# Altium schematic sheet ESP8266_DEVKIT_RELAY.SchDoc(ESP8266_DEVKIT_RELAY)
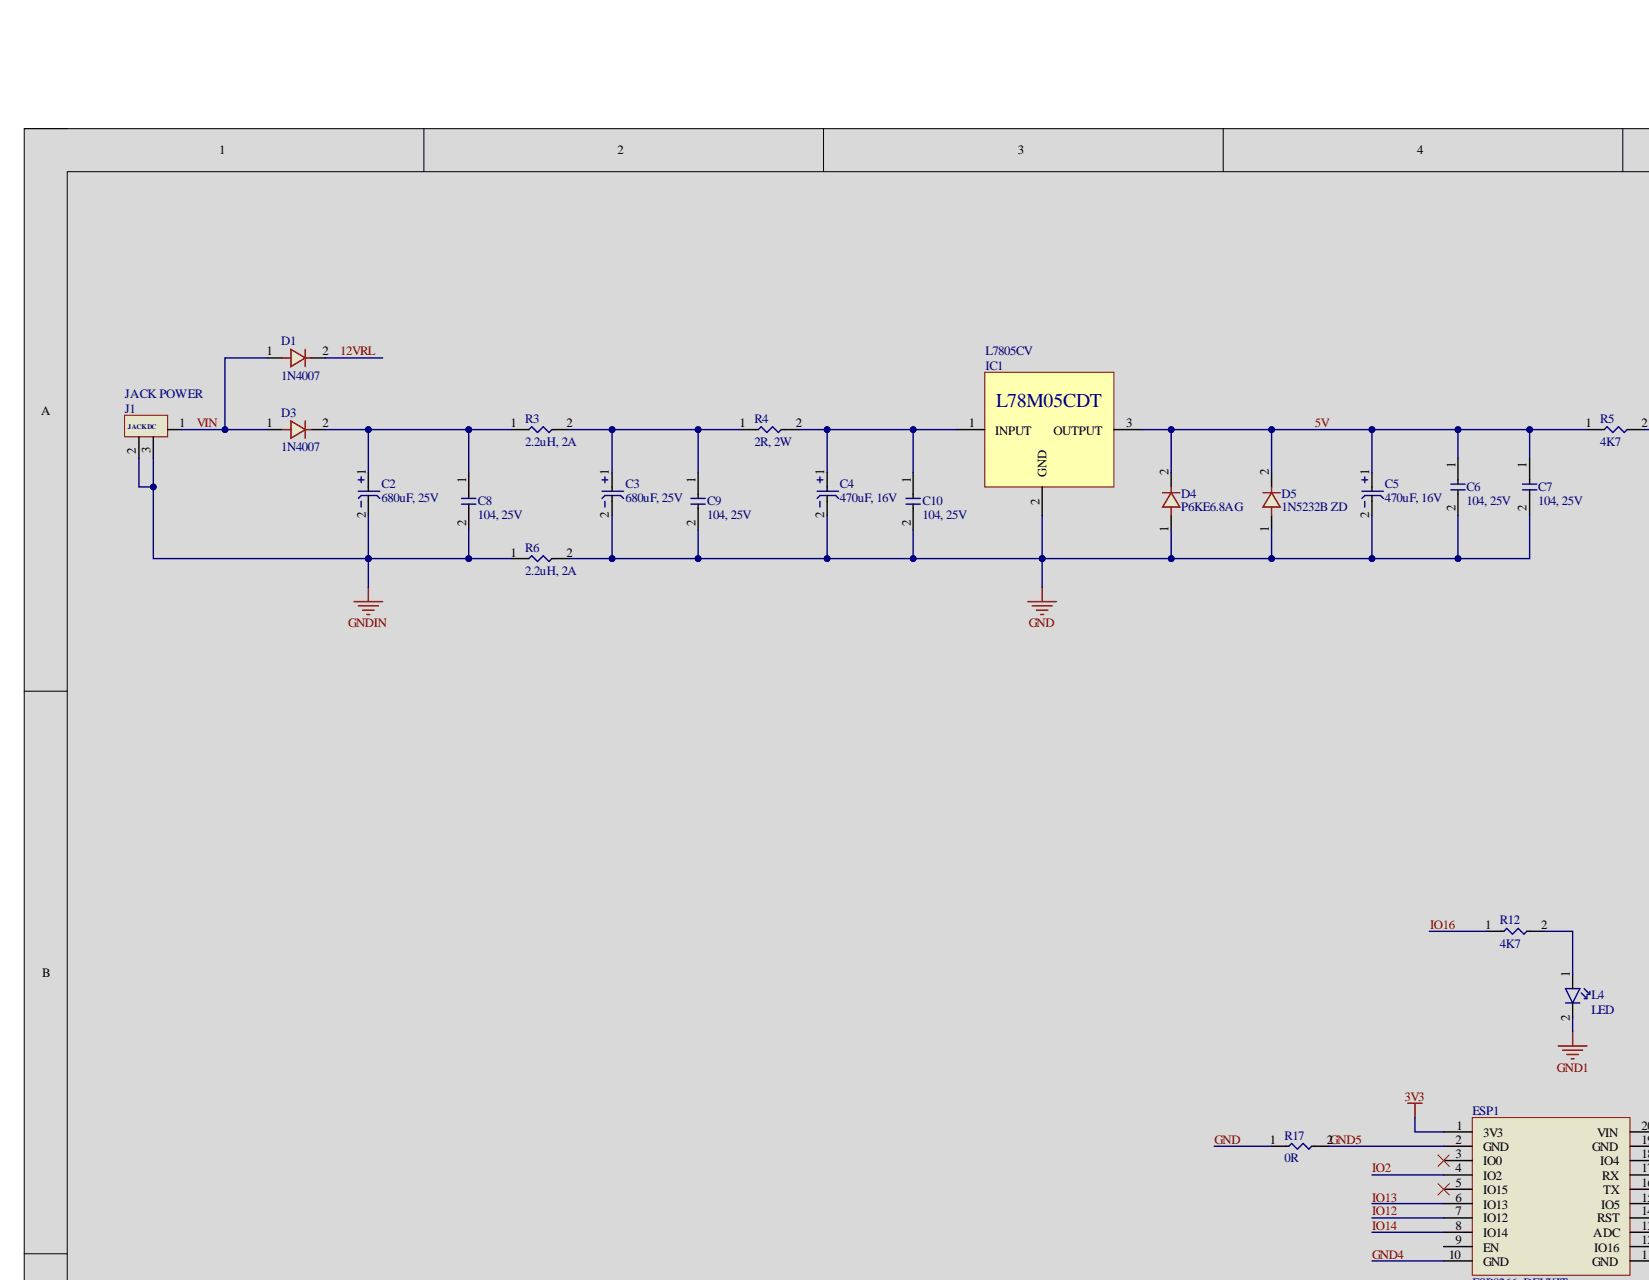
[diagram p0: ESP8266_DEVKIT_RELAY.SchDoc, top left]
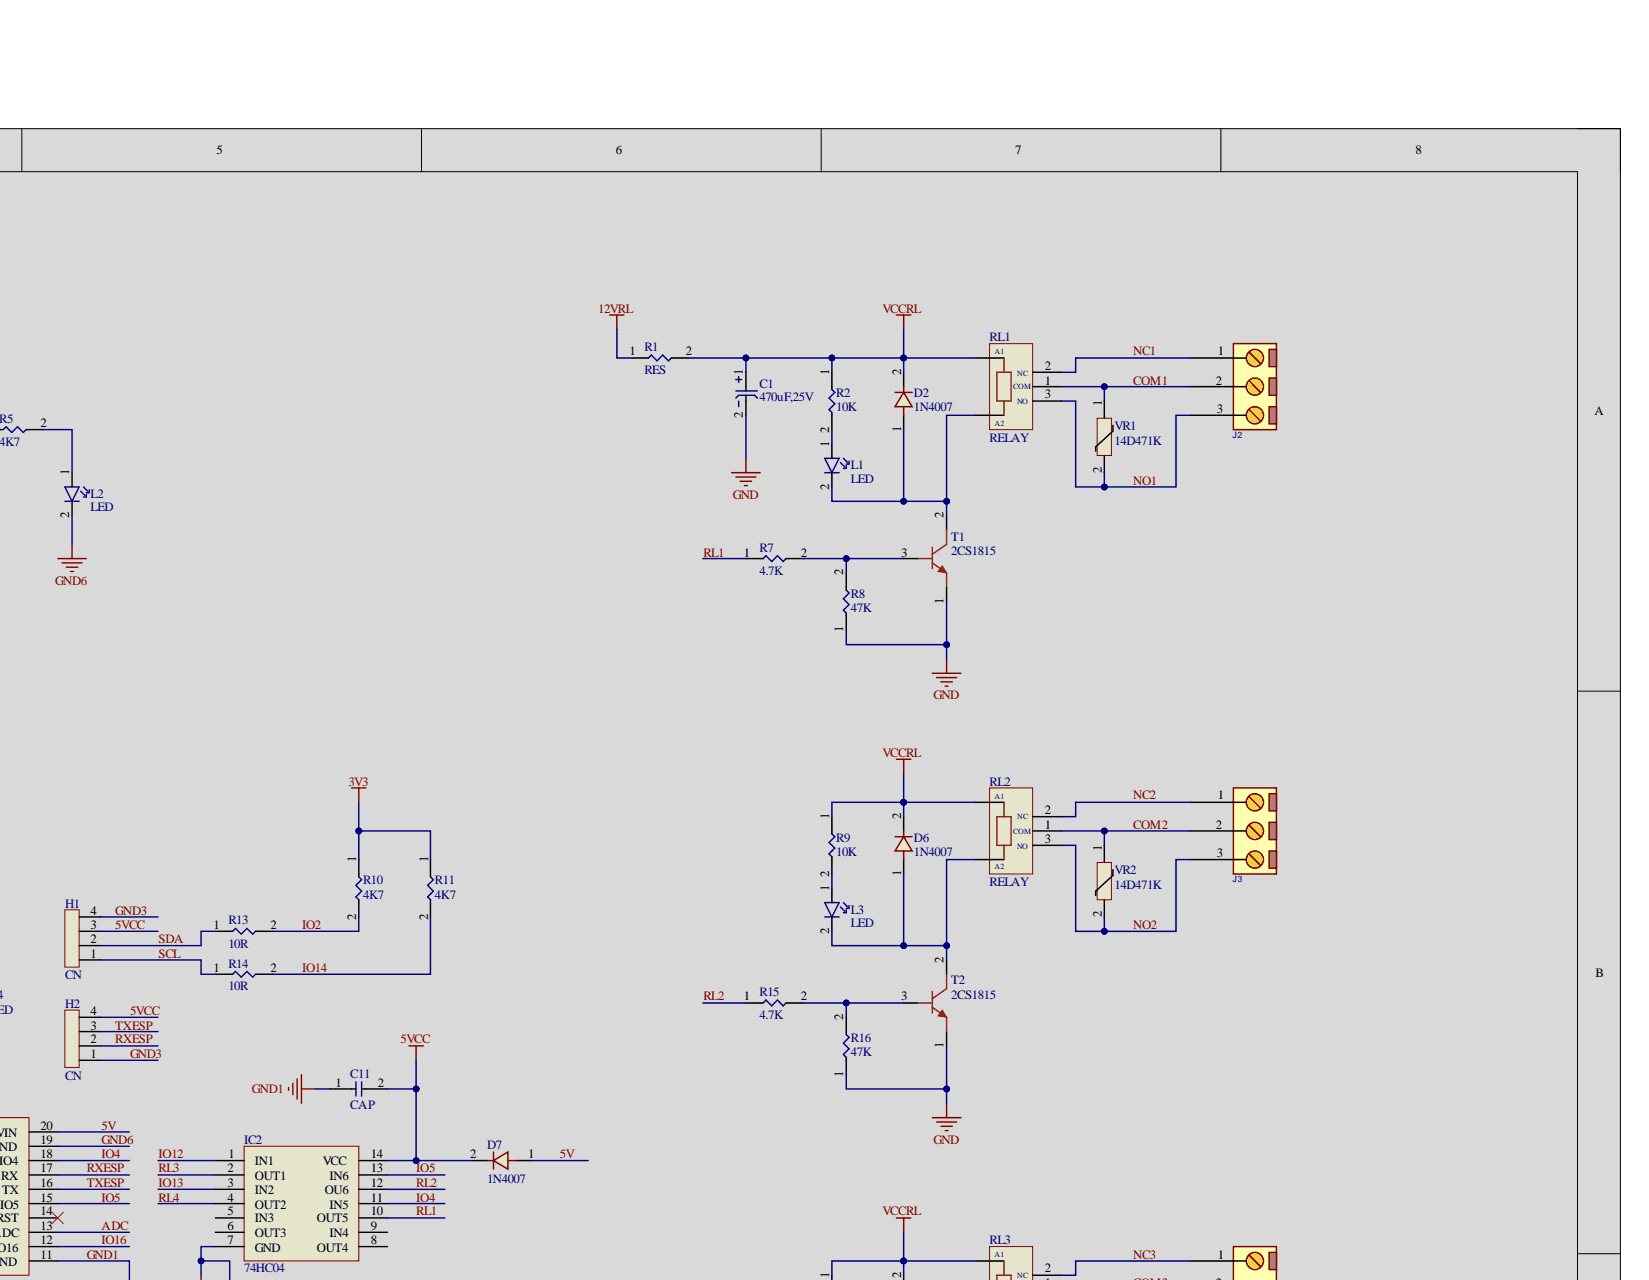
[diagram p1: ESP8266_DEVKIT_RELAY.SchDoc, top right]
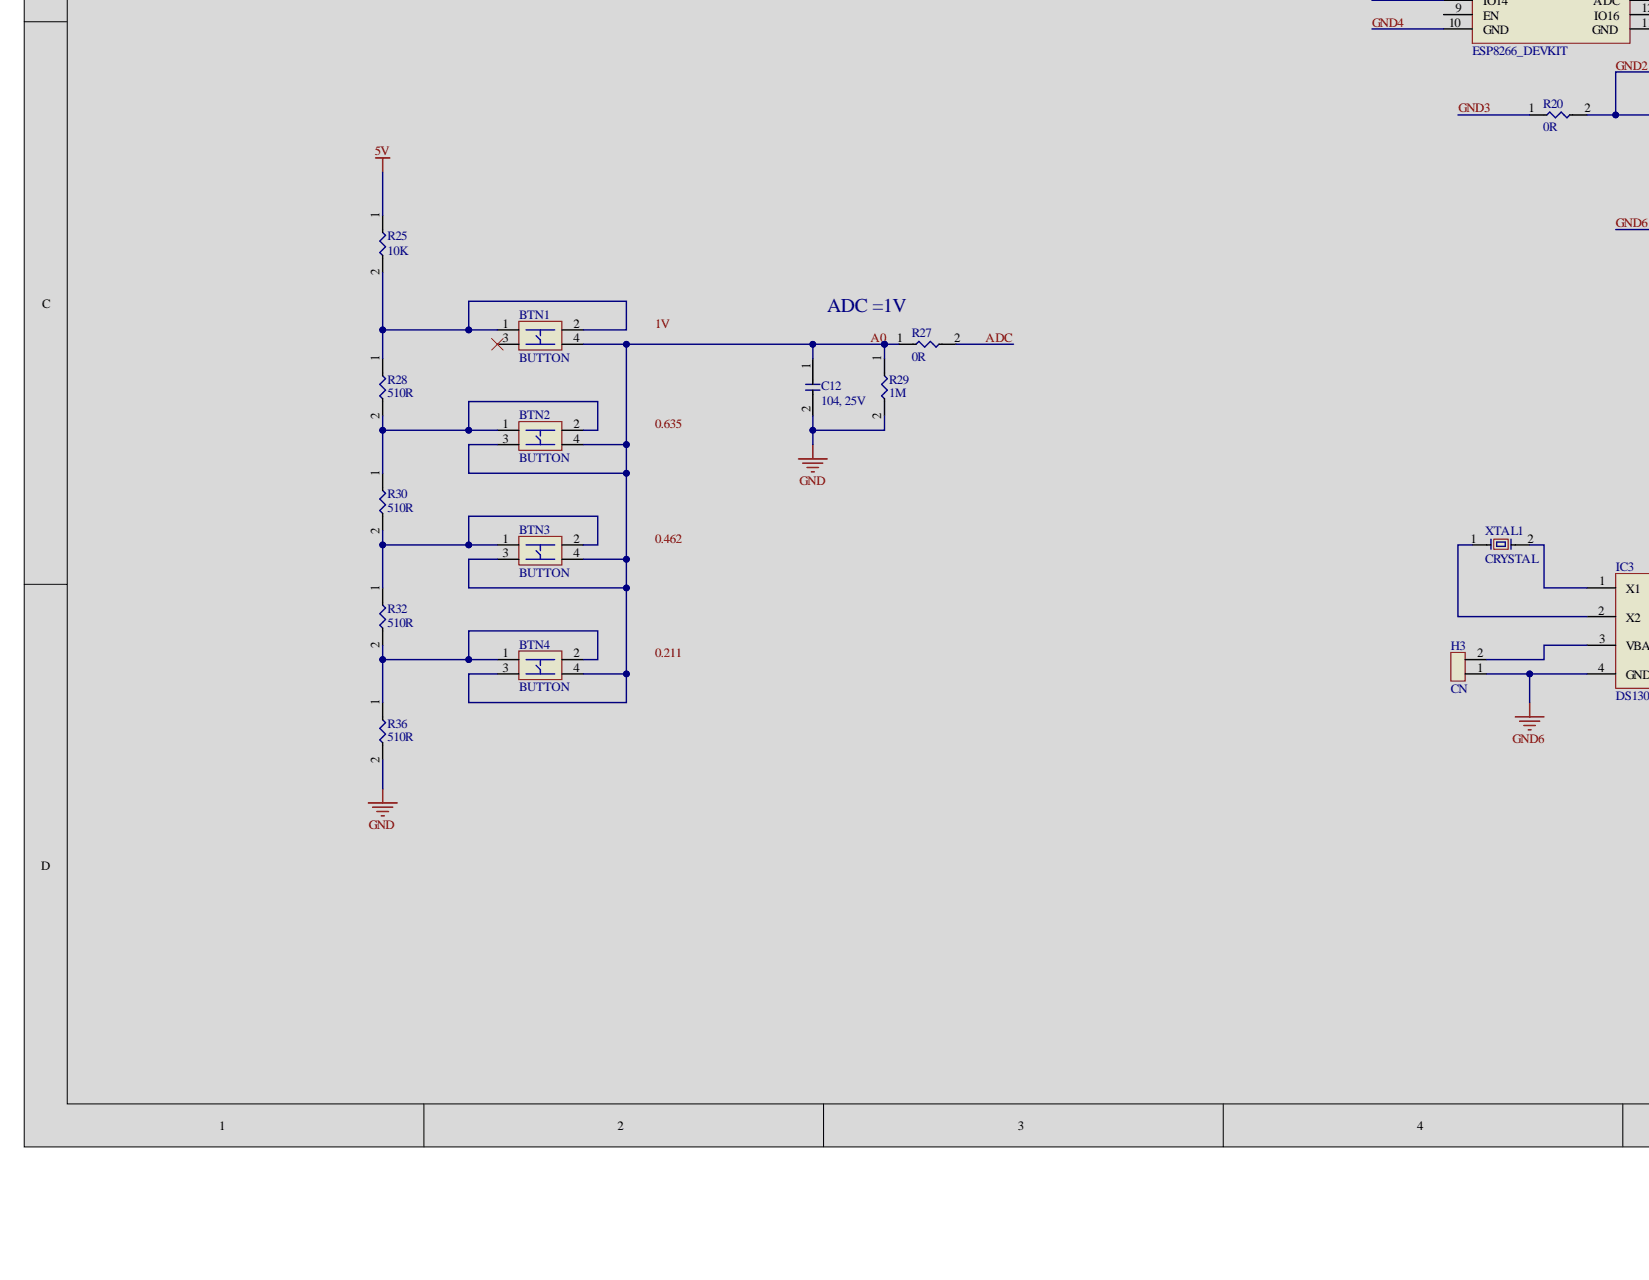
[diagram p2: ESP8266_DEVKIT_RELAY.SchDoc, bottom left]
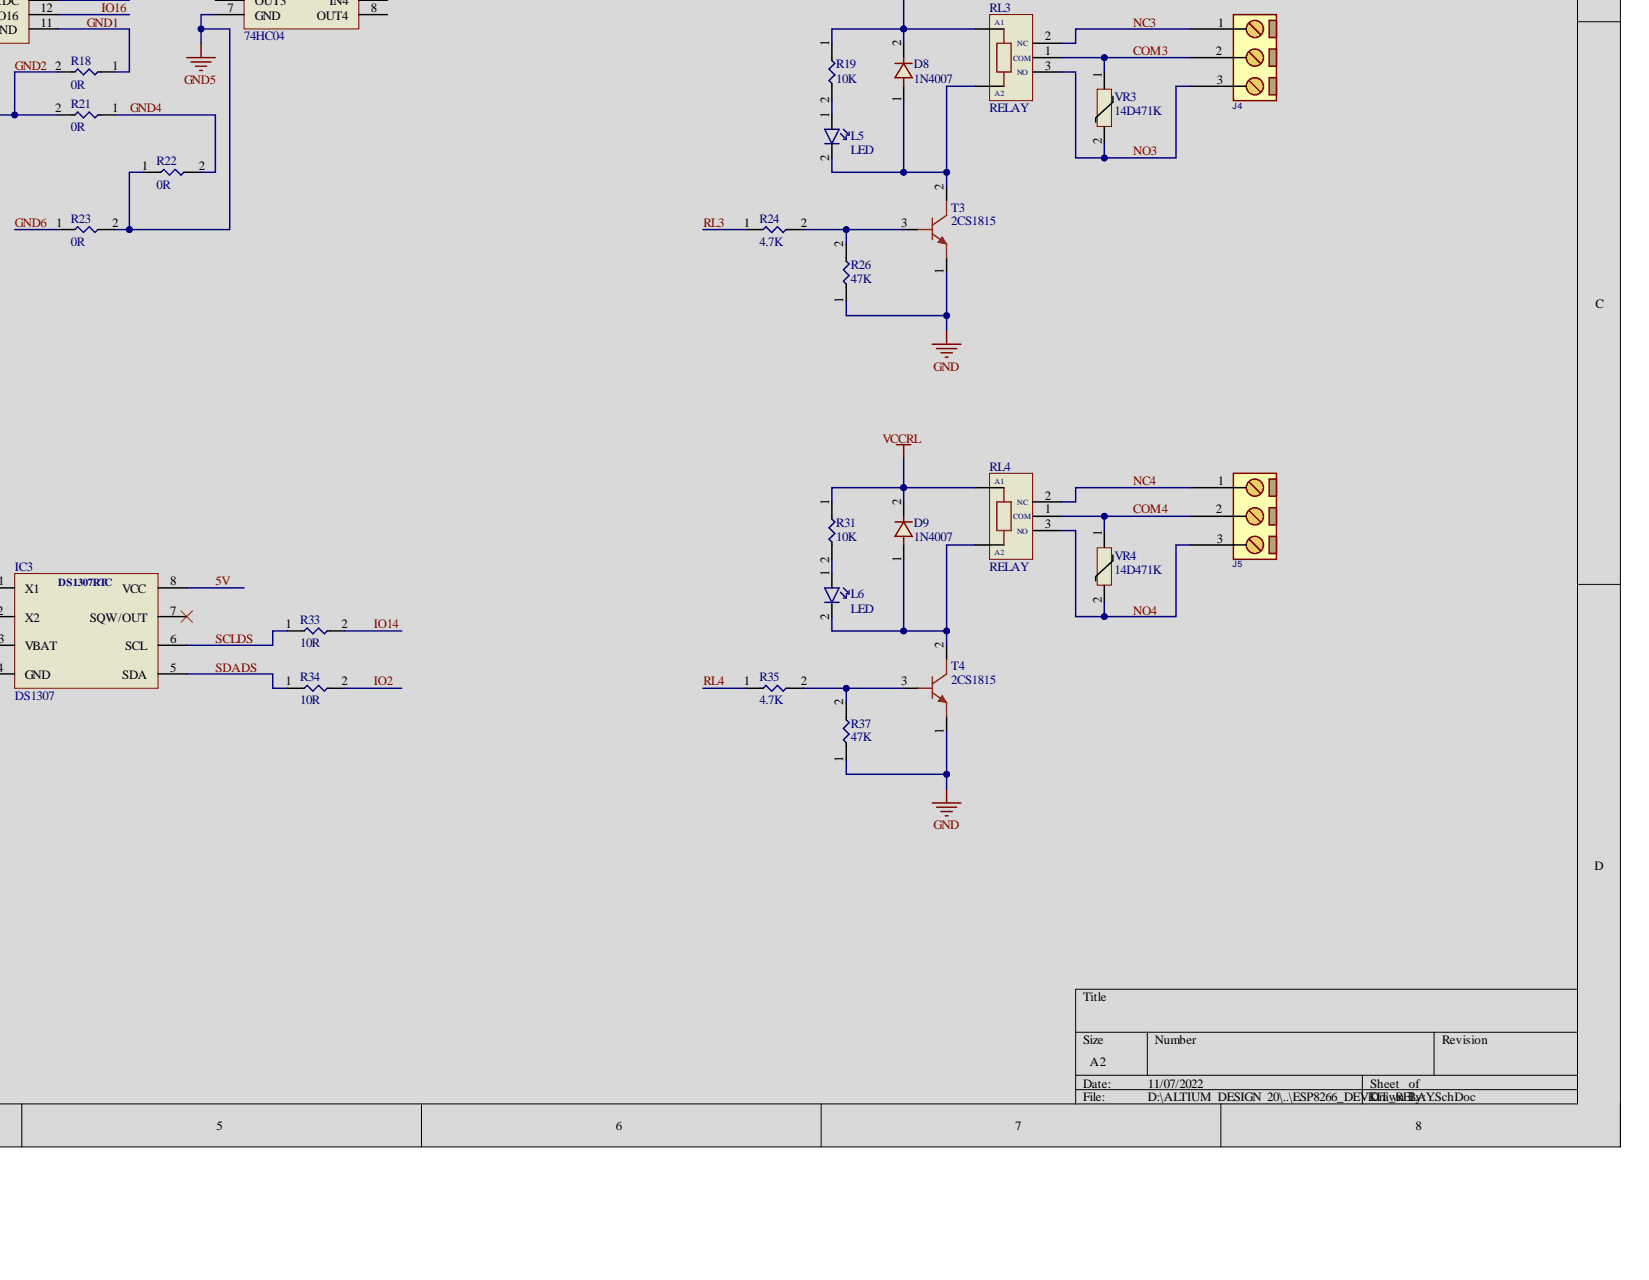
[diagram p3: ESP8266_DEVKIT_RELAY.SchDoc, bottom right]

## Parts
BTN1  BUTTON  [6X6X8MM]
BTN2  BUTTON  [6X6X8MM]
BTN3  BUTTON  [6X6X8MM]
BTN4  BUTTON  [6X6X8MM]
C1  470uF,25V  [CAP_POL_35MM]
C2  680uF, 25V  [CAP_POL_DIP]
C3  680uF, 25V  [CAP_POL_DIP]
C4  470uF, 16V  [CAP_POL_35MM]
C5  470uF, 16V  [CAP_POL_35MM]
C6  104, 25V  [CAP_CER_DC]
C7  104, 25V  [CAP_CER_DC]
C8  104, 25V  [CAP_CER_DC]
C9  104, 25V  [CAP_CER_DC]
C10  104, 25V  [CAP_CER_DC]
C11  CAP  [CAP_CER_DC]
C12  104, 25V  [CAP_CER_DC]
D1  1N4007  [DO-41]
D2  1N4007  [DO-41]
D3  1N4007  [DO-41]
D4  P6KE6.8AG  [DO-41]
D5  1N5232B ZD  [DO-41]
D6  1N4007  [DO-41]
D7  1N4007  [DO-41]
D8  1N4007  [DO-41]
D9  1N4007  [DO-41]
ESP1  ESP8266_DEVKIT  [ESP8266_DEVKIT]
H1  CN  [4P_DT_254MM]
H2  CN  [4P_DT_254MM]
H3  CN  [2P_254MM_T]
IC1  L7805CV  [TO-220]
IC2  74HC04  [DIP14]
IC3  DS1307  [DIP8]
J1  JACK POWER  [DC POWER]
J2  CONN_TB3  [P_TBG_3]  (value not drawn)
J3  CONN_TB3  [P_TBG_3]  (value not drawn)
J4  CONN_TB3  [P_TBG_3]  (value not drawn)
J5  CONN_TB3  [P_TBG_3]  (value not drawn)
L1  LED  [LED_3MM_DIP]
L2  LED  [LED_3MM_DIP]
L3  LED  [LED_3MM_DIP]
L4  LED  [LED_3MM_DIP]
L5  LED  [LED_3MM_DIP]
L6  LED  [LED_3MM_DIP]
R1  RES  [R2W_B]
R2  10K  [R_DIP_025W]
R3  2.2uH, 2A  [R2W_B]
R4  2R, 2W  [R2W_B]
R5  4K7  [R_DIP_025W]
R6  2.2uH, 2A  [R2W_B]
R7  4.7K  [R_DIP_025W]
R8  47K  [R_DIP_025W]
R9  10K  [R_DIP_025W]
R10  4K7  [0805_R_L]
R11  4K7  [0805_R_L]
R12  4K7  [R_DIP_025W]
R13  10R  [R_DIP_025W]
R14  10R  [R_DIP_025W]
R15  4.7K  [R_DIP_025W]
R16  47K  [R_DIP_025W]
R17  0R  [R_DIP_025W]
R18  0R  [R_DIP_025W]
R19  10K  [R_DIP_025W]
R20  0R  [R_DIP_025W]
R21  0R  [R_DIP_025W]
R22  0R  [R_DIP_025W]
R23  0R  [R_DIP_025W]
R24  4.7K  [R_DIP_025W]
R25  10K  [R_DIP_025W]
R26  47K  [R_DIP_025W]
R27  0R  [R_DIP_025W]
R28  510R  [R_DIP_025W]
R29  1M  [R_DIP_025W]
R30  510R  [R_DIP_025W]
R31  10K  [R_DIP_025W]
R32  510R  [R_DIP_025W]
R33  10R  [R_DIP_025W]
R34  10R  [R_DIP_025W]
R35  4.7K  [R_DIP_025W]
R36  510R  [R_DIP_025W]
R37  47K  [R_DIP_025W]
RL1  RELAY  [5V_10A]
RL2  RELAY  [5V_10A]
RL3  RELAY  [5V_10A]
RL4  RELAY  [5V_10A]
T1  2CS1815  [2CS1815]
T2  2CS1815  [2CS1815]
T3  2CS1815  [2CS1815]
T4  2CS1815  [2CS1815]
VR1  14D471K  [VARISTOR]
VR2  14D471K  [VARISTOR]
VR3  14D471K  [VARISTOR]
VR4  14D471K  [VARISTOR]
XTAL1  CRYSTAL  [CRL_32768KHZ]

## Nets
3V3: ESP1.1(3V3) R10.1 R11.1
GNDIN: C2.2 C8.2 J1.2 J1.3 R6.1
VCCRL: C1.1 D2.2 D6.2 D8.2 D9.2 R1.2 R2.1 R9.1 R19.1 R31.1 RL1.5 RL2.5 RL3.5 RL4.5
5V: C5.1 C6.1 C7.1 D4.2 D5.2 D7.1 ESP1.20(VIN) IC1.3 IC3.8(VCC) R5.1 R25.1
5VCC: C11.2 D7.2 H1.3 H2.4 IC2.14(VCC)
12VRL: D1.2 R1.1
A0: BTN1.4 BTN2.3 BTN2.4 BTN3.3 BTN3.4 BTN4.3 BTN4.4 C12.1 R27.1 R29.1
ADC: ESP1.13(ADC) R27.2
COM1: J2.2 RL1.1(COM) VR1.1
COM2: J3.2 RL2.1(COM) VR2.1
COM3: J4.2 RL3.1(COM) VR3.1
COM4: J5.2 RL4.1(COM) VR4.1
GND: C1.2 C3.2 C4.2 C5.2 C6.2 C7.2 C9.2 C10.2 C12.2 D4.1 D5.1 IC1.2 R6.2 R8.1 R16.1 R17.1 R26.1 R29.2 R36.2 R37.1 T1.1 T2.1 T3.1 T4.1
GND1: C11.1 ESP1.11(GND) L4.2 R18.1
GND2: R18.2 R20.2 R21.2
GND3: H1.4 H2.1 R20.1
GND4: ESP1.10(GND) R21.1 R22.2
GND5: ESP1.2(GND) IC2.7(GND) R17.2 R22.1 R23.2
GND6: ESP1.19(GND) H3.1 IC3.4(GND) L2.2 R23.1
IO2: ESP1.4(IO2) R10.2 R13.2 R34.2
IO4: ESP1.18(IO4) IC2.11(IN5)
IO5: ESP1.15(IO5) IC2.13(IN6)
IO12: ESP1.7(IO12) IC2.1(IN1)
IO13: ESP1.6(IO13) IC2.3(IN2)
IO14: ESP1.8(IO14) R11.2 R14.2 R33.2
IO16: ESP1.12(IO16) R12.1
NC1: J2.1 RL1.2(NC)
NC2: J3.1 RL2.2(NC)
NC3: J4.1 RL3.2(NC)
NC4: J5.1 RL4.2(NC)
NO1: J2.3 RL1.3(NO) VR1.2
NO2: J3.3 RL2.3(NO) VR2.2
NO3: J4.3 RL3.3(NO) VR3.2
NO4: J5.3 RL4.3(NO) VR4.2
RL1: IC2.10(OUT5) R7.1
RL2: IC2.12(OU6) R15.1
RL3: IC2.2(OUT1) R24.1
RL4: IC2.4(OUT2) R35.1
RXESP: ESP1.17(RX) H2.2
SCL: H1.1 R14.1
SCLDS: IC3.6(SCL) R33.1
SDA: H1.2 R13.1
SDADS: IC3.5(SDA) R34.1
TXESP: ESP1.16(TX) H2.3
VIN: D1.1 D3.1 J1.1
NetBTN1_1: BTN1.1 BTN1.2 R25.2 R28.1
NetBTN2_1: BTN2.1 BTN2.2 R28.2 R30.1
NetBTN3_1: BTN3.1 BTN3.2 R30.2 R32.1
NetBTN4_1: BTN4.1 BTN4.2 R32.2 R36.1
NetC2_1: C2.1 C8.1 D3.2 R3.1
NetC3_1: C3.1 C9.1 R3.2 R4.1
NetC4_1: C4.1 C10.1 IC1.1 R4.2
NetD2_1: D2.1 L1.2 RL1.4 T1.2
NetD6_1: D6.1 L3.2 RL2.4 T2.2
NetD8_1: D8.1 L5.2 RL3.4 T3.2
NetD9_1: D9.1 L6.2 RL4.4 T4.2
NetH3_2: H3.2 IC3.3(VBAT)
NetIC3_1: IC3.1(X1) XTAL1.2
NetIC3_2: IC3.2(X2) XTAL1.1
NetL1_1: L1.1 R2.2
NetL2_1: L2.1 R5.2
NetL3_1: L3.1 R9.2
NetL4_1: L4.1 R12.2
NetL5_1: L5.1 R19.2
NetL6_1: L6.1 R31.2
NetR7_2: R7.2 R8.2 T1.3
NetR15_2: R15.2 R16.2 T2.3
NetR24_2: R24.2 R26.2 T3.3
NetR35_2: R35.2 R37.2 T4.3
0.211:
0.462:
0.635:
1V:

Not connected: BTN1 3; ESP1 3(IO0) 5(IO15) 9(EN) 14(RST); IC2 5(IN3) 6(OUT3) 8(OUT4) 9(IN4); IC3 7(SQW/OUT)

## Library data of the parts
BTN1-BTN4 = BTN4P: Component Kind: Standard; Library Name: COMPONENT.IntLib
C1-C5 = CAP_ALU: Component Kind: Standard; Library Name: COMPONENT.IntLib
C6-C12 = CAPACITOR: Component Kind: Standard; Library Name: COMPONENT.IntLib
D4 D5 = 1N4007: Component Kind: Standard; Library Name: COMPONENT.IntLib
H1 H2 = 4x01p: Component Kind: Standard; Library Name: COMPONENT.IntLib
H3 = 2x01p: Component Kind: Standard; Library Name: COMPONENT.IntLib
J1 = JACK DC: Component Kind: Standard; Library Name: COMPONENT.IntLib
J2-J5 = TB3 GREEN: CONN, TERMINAL BLOCK, 5.08mm pitch; Component Kind: Standard; Library Name: TERMINAL.IntLib; VALUE: CONN_TB3
R1-R37 = RESISTOR: TYPE DIP & SMD; Component Kind: Standard; Library Name: COMPONENT.IntLib
RL1-RL4 = SONGLE-5P-10A: Component Kind: Standard; Library Name: COMPONENT.IntLib
VR1-VR4 = MOV: Component Kind: Standard; Library Name: COMPONENT.IntLib
XTAL1 = XTAL2P: Component Kind: Standard; Library Name: COMPONENT.IntLib
D1-D3 D6-D9 = 1N4007: Component Kind: Standard; Library Name: COMPONENT.IntLib
ESP1 = ESP8266_DEVKIT: Component Kind: Standard; Library Name: MODULE.IntLib
IC1 = L7805CV: Component Kind: Standard; Library Name: POWER_SUPPLY_ICs.IntLib
IC2 = 74HC04: Component Kind: Standard; Library Name: INTERFACE_CONVERTER.IntLib
IC3 = DS1307: Component Kind: Standard; Library Name: MEMORY_RTC_CLOCK.IntLib
L1-L6 = LED: Component Kind: Standard; Library Name: COMPONENT.IntLib
T1-T4 = 2CS1815: Component Kind: Standard; Library Name: COMPONENT.IntLib
